# Altium schematic sheet Psoc4.SchDoc
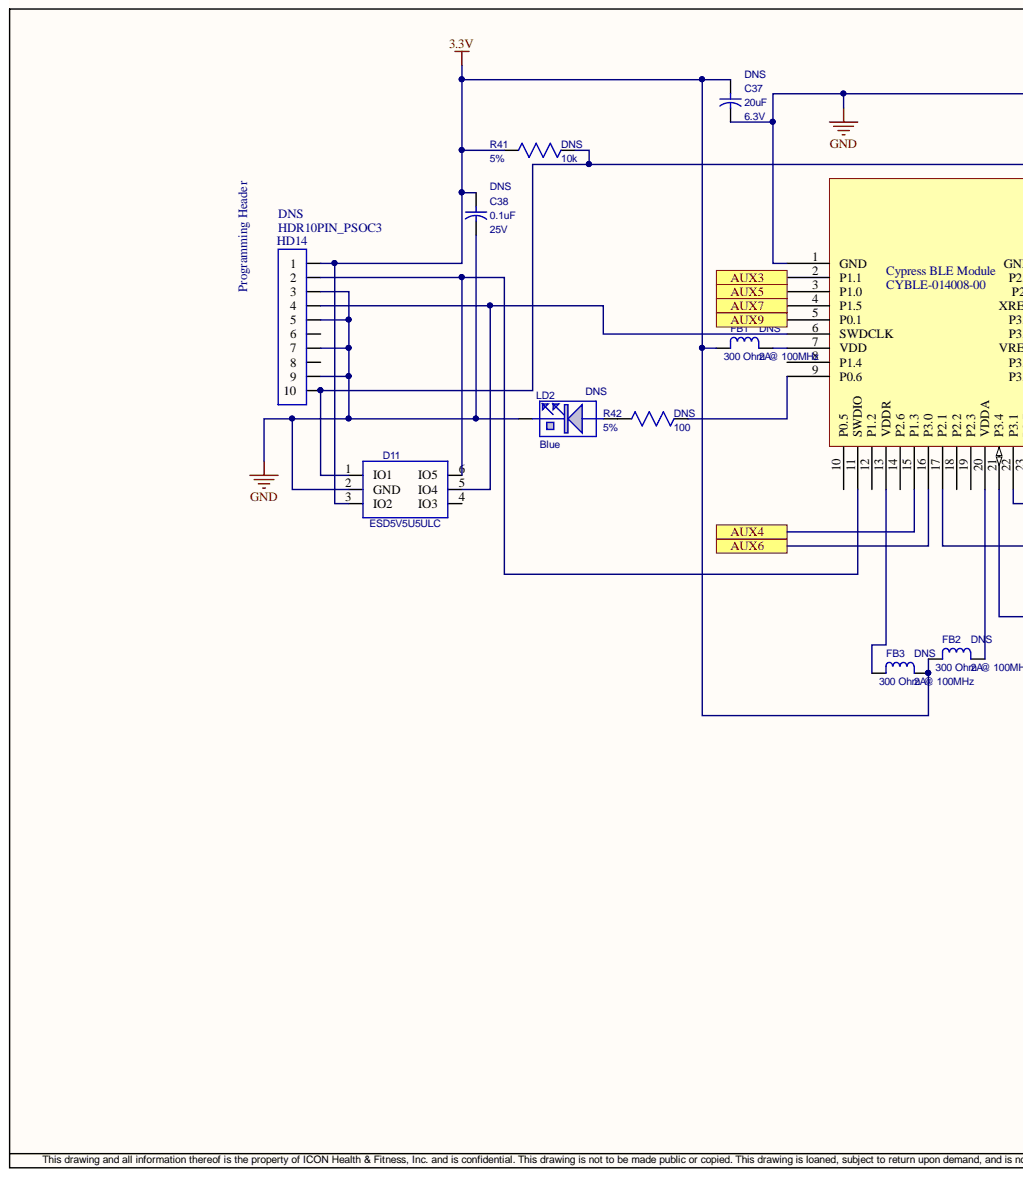
[diagram p0: Psoc4.SchDoc, left]
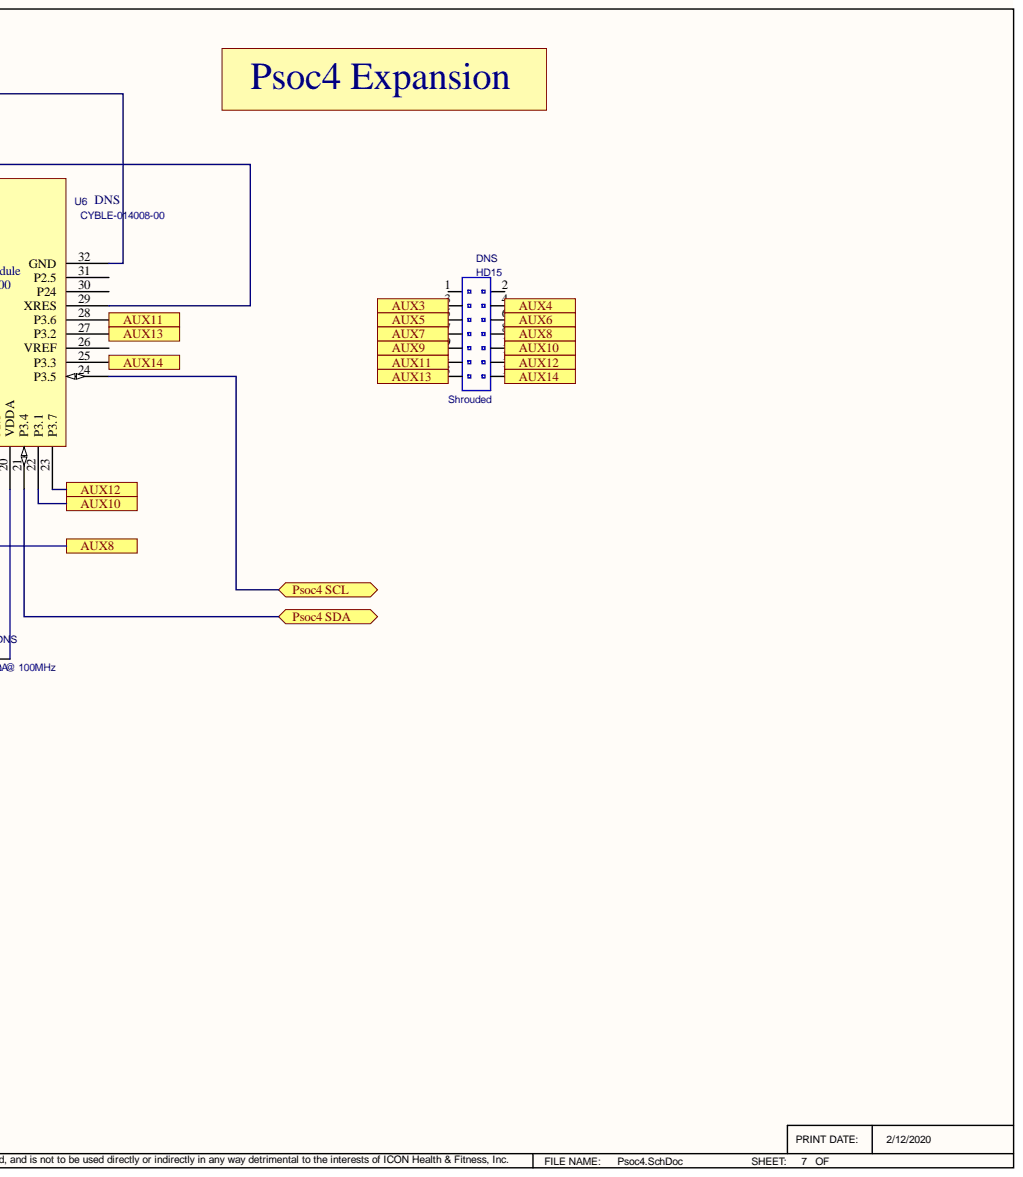
[diagram p1: Psoc4.SchDoc, right]

## Parts
C37  value 20uF  Voltage: 6.3V  [0402]  (hidden comment: 20uF_0402)
C38  value 0.1uF  Voltage: 25V  [C0201]  (hidden comment: CAP_NON_POLAR_SMT)
D11  ESD5V5U5ULC  [SOT457-6]
FB1  value 300 Ohm @ 100MHz  Amperage: 2A  [0603]  (hidden comment: FERRITE_BEAD)
FB2  value 300 Ohm @ 100MHz  Amperage: 2A  [0603]  (hidden comment: FERRITE_BEAD)
FB3  value 300 Ohm @ 100MHz  Amperage: 2A  [0603]  (hidden comment: FERRITE_BEAD)
HD14  HDR10PIN_PSOC3  ComponentType: Header  Notes: Programming Header  [HDR10PIN_PSOC3]
HD15  HeaderType: Shrouded  [HDR_2.5MM_14PIN_JST_XAD]  (hidden comment: HDR_14PIN_DUALROW_JST)
LD2  Color: Blue  [LED0603REFLOW-IPC]  (hidden comment: 0603_BLUE)
R41  value 10k  Tolerance: 5%  [0402]  (hidden comment: RESISTOR_SMT_5%)
R42  value 100  Tolerance: 5%  [0402]  (hidden comment: RESISTOR_SMT_5%)
U6  CYBLE-014008-00  ChipType: BLE Module  Package: Module  Vendor: Cypress CYBLE-014008-00  VendorName: Cypress  [CYBLE-014008-00]

## Ports
AUX3, AUX4, AUX5, AUX6, AUX7, AUX8, AUX9, AUX10, AUX11, AUX12, AUX13, AUX14, Psoc4 SCL, Psoc4 SDA

## Nets
3.3V: C37.1 C38.1 D11.3(IO2) FB1.1 FB2.1 FB3.2 HD14.1 R41.2
GND: C37.2 C38.2 D11.2(GND) HD14.3 HD14.5 HD14.7 HD14.9 LD2.1 U6.1(GND) U6.32(GND)
AUX3: HD15.3 U6.2(P1.1) ; port AUX3
AUX4: HD15.4 U6.15(P1.3) ; port AUX4
AUX5: HD15.5 U6.3(P1.0) ; port AUX5
AUX6: HD15.6 U6.16(P3.0) ; port AUX6
AUX7: HD15.7 U6.4(P1.5) ; port AUX7
AUX8: HD15.8 U6.17(P2.1) ; port AUX8
AUX9: HD15.9 U6.5(P0.1) ; port AUX9
AUX10: HD15.10 U6.22(P3.1) ; port AUX10
AUX11: HD15.11 U6.28(P3.6) ; port AUX11
AUX12: HD15.12 U6.23(P3.7) ; port AUX12
AUX13: HD15.13 U6.27(P3.2) ; port AUX13
AUX14: HD15.14 U6.25(P3.3) ; port AUX14
Psoc4 SCL: U6.24(P3.5) ; port Psoc4 SCL
Psoc4 SDA: U6.21(P3.4) ; port Psoc4 SDA
NetD11_1: D11.1(IO1) HD14.10 R41.1 U6.29(XRES)
NetD11_5: D11.5(IO4) HD14.4 U6.6(SWDCLK)
NetD11_6: D11.6(IO5) HD14.2 U6.11(SWDIO)
NetFB1_2: FB1.2 U6.7(VDD)
NetFB2_2: FB2.2 U6.20(VDDA)
NetFB3_1: FB3.1 U6.13(VDDR)
NetLD2_2: LD2.2 R42.2
NetR42_1: R42.1 U6.9(P0.6)

Not connected: D11 4(IO3); HD14 6 8; HD15 1 2; U6 8(P1.4) 10(P0.5) 12(P1.2) 14(P2.6) 18(P2.2) 19(P2.3) 26(VREF) 30(P24) 31(P2.5)

## Library data of the parts
C37 = 295734: Capacitor, 20uF, 6.3V, Monolithic, +/- 5%, Non-Polar, 0402, 2; Database Table Name: AltiumComponents; Tolerance: +/- 5%; CapType: Monolithic; Component Kind: Standard; ComponentLink1Description: Datasheet; ComponentLink4Description: PDM Parts Maintenance; ComponentLink4URL: https://pdm.iconfitness.com/PDM/EBOM/PartsMaintenance/PartsMaintenance.aspx?Editplidx=295734; ComponentType: Capacitor; Cost: 0.001; DNS: DNS; ModifyDate: 4/13/10; Package: 0402; Pins: 2; plIDX: 295734; Polarity: Non-Polar; Supplier 1: Digi-Key; CheckedBy: gdeguzman; CreatedBy: Brian Beardall; LastUpdatedBy: Brian Beardall; Library Name: bome.DbLib
C38 = 304055: Capacitor, 0.1uF, 25V, X5R, Ceramic, -20/+20%, Non-Polar, 0201, Fenghua: 0201X104M250NT or equivalent; Database Table Name: AltiumComponents; CapType: X5R; Component Kind: Standard; ComponentLink4Description: PDM Parts Maintenance; ComponentLink4URL: https://pdm.iconfitness.com/PDM/EBOM/PartsMaintenance/PartsMaintenance.aspx?Editplidx=304055; ComponentType: Capacitor; Composition: Ceramic; Cost: 0; DNS: DNS; Package: 0201; plIDX: 304055; Polarity: Non-Polar; Tolerance: -20/+20%; Vendor: Fenghua: 0201X104M250NT or equivalent; CheckedBy: Sam Rhoufiry; CreatedBy: Sara Lin; LastUpdatedBy: Steven Zhou; Library Name: bome.DbLib
D11 = 325030: Diode, ESD5V5U5ULC, TVS, 5V, TSOP6, Infineon: ESD5V5U5ULC; Database Table Name: AltiumComponents; IDFCOMP: 0; Component Kind: Standard; ComponentLink1Description: Datasheet; ComponentLink1URL: \\iconfitness.com\loganfiles\RND Departments\electronics\accel_lib\datasheets\Infineon-ESD5V5U5ULC-DS-v01_04-EN.pdf; ComponentLink4Description: PDM Parts Maintenance; ComponentLink4URL: https://pdm.iconfitness.com/PDM/EBOM/PartsMaintenance/PartsMaintenance.aspx?Editplidx=325030; ComponentType: Diode; Cost: 0.08; Datasheet: \\langley\electronics\accel_lib\datasheets\D\PESDXS4UD-NXP.pdf; DiodeType: TVS; ModifyDate: 07/31/2009 12:30:23; Package: TSOP6; plIDX: 325030; Value: ESD5V5U5ULC; Vendor: Infineon: ESD5V5U5ULC; Voltage: 5V; CheckedBy: T. Hayes; CreatedBy: Rui Zhang; LastUpdatedBy: Rui Zhang; Library Name: bome.DbLib
FB1-FB3 = 295882: Inductor, 300 Ohm @ 100MHz, Ferrite Bead, SMT, 0603 (1608 Metric), 2A, 2 Pins, Wurth Electronics: 742792641 or equivalent; Database Table Name: AltiumComponents; Component Kind: Standard; ComponentLink4Description: PDM Parts Maintenance; ComponentLink4URL: https://pdm.iconfitness.com/PDM/EBOM/PartsMaintenance/PartsMaintenance.aspx?Editplidx=295882; ComponentType: Inductor; Cost: 0; DNS: DNS; InductorType: Ferrite Bead; Leads: SMT; Package: 0603 (1608 Metric); Pins: 2; plIDX: 295882; Vendor: Wurth Electronics: 742792641 or equivalent; CreatedBy: Mike Tyger; LastUpdatedBy: Mike Tyger; Library Name: bome.DbLib
  FB1: Value: 300 Ohm @ 100MHz
HD14 = 18843: Header, 10 Pins, Samtec: FTSH-105-01-L-DV-K; Database Table Name: AltiumComponents; Component Kind: Standard; ComponentLink4Description: PDM Parts Maintenance; ComponentLink4URL: https://pdm.iconfitness.com/PDM/EBOM/PartsMaintenance/PartsMaintenance.aspx?Editplidx=18843; Cost: 0.15; DNS: DNS; Pin: 10-pin; Pins: 10; plIDX: 18843; Value: FTSH-105-01-L-DV-K; Vendor: Samtec: FTSH-105-01-L-DV-K; Library Name: bome.DbLib
HD15 = 365385: Header, 14 Pins, 2.50mm, Vertical, Through hole, double row, Shrouded, JST: B14B-XADSS-N-A; Database Table Name: AltiumComponents; Component Kind: Standard; ComponentLink1Description: Datasheet; ComponentLink1URL: \\iconfitness.com\loganfiles\RND Departments\electronics\accel_lib\Datasheets\eXA1.pdf; ComponentLink4Description: PDM Parts Maintenance; ComponentLink4URL: https://pdm.iconfitness.com/PDM/EBOM/PartsMaintenance/PartsMaintenance.aspx?Editplidx=365385; ComponentType: Header; Cost: 0; DNS: DNS; LeadSpacing: 2.50mm; Package: Vertical, Through hole, double row; Pins: 14; plIDX: 365385; Value: B14B-XADSS-N-A; Vendor: JST: B14B-XADSS-N-A; CreatedBy: Ross Bunnell; LastUpdatedBy: Ross Bunnell; Library Name: bome.DbLib
LD2 = 16659: LED, Blue, Ultrabright SMD, 0603, Vendor: Lite-on, LTST-C190UBKT; Database Table Name: AltiumComponents; IDF_COMP: 1; PackageOutlineLayer: PackageOutline; ComponentHeight: 32mil; Component Kind: Standard; ComponentLink4Description: PDM Parts Maintenance; ComponentLink4URL: https://pdm.iconfitness.com/PDM/EBOM/PartsMaintenance/PartsMaintenance.aspx?Editplidx=16659; ComponentType: LED; Cost: 0.08; DNS: DNS; HelpURL: http://www.laureanno.com/RC/LED-calc.htm; LEDType: Ultrabright SMD; ModifyDate: 02/08/2007 16:01:09; Package: 0603; Pins: 2; plIDX: 16659; Supplier 1: Digi-Key; Supplier Part Number 1: LTST-C190UBKT-ND; Vendor: Lite-on; VendorNumber: LTST-C190UBKT; CheckedBy: Scott Travis; Datasheet: G:\accel_lib\datasheets\LTST-C190UBKT.pdf; LastUpdatedBy: Kimberly Barker; Library Name: bome.DbLib
R41 = 19590: Resistor, 10k, ThickFilm, 5%, 0402, 1/10W, Any; Database Table Name: AltiumComponents; Component Kind: Standard; ComponentLink4Description: PDM Parts Maintenance; ComponentLink4URL: https://pdm.iconfitness.com/PDM/EBOM/PartsMaintenance/PartsMaintenance.aspx?Editplidx=19590; ComponentType: Resistor; Composition: ThickFilm; Cost: 0.0004; DNS: DNS; Package: 0402; plIDX: 19590; Vendor: Any; Wattage: 1/10W; CheckedBy: gdeguzman; LastUpdatedBy: Mike Tyger; Library Name: bome.DbLib
R42 = 20445: Resistor, 100, ThickFilm, 5%, 0402, 1/10W, Any; Database Table Name: AltiumComponents; Component Kind: Standard; ComponentLink4Description: PDM Parts Maintenance; ComponentLink4URL: https://pdm.iconfitness.com/PDM/EBOM/PartsMaintenance/PartsMaintenance.aspx?Editplidx=20445; ComponentType: Resistor; Composition: ThickFilm; Cost: 0.001; DNS: DNS; Package: 0402; plIDX: 20445; Vendor: Any; Wattage: 1/10W; CheckedBy: gdeguzman; LastUpdatedBy: Mike Tyger; Library Name: bome.DbLib
U6 = 309168: IC, BLE Module, Module, 31 Pins, Cypress CYBLE-014008-00; Database Table Name: AltiumComponents; Component Kind: Standard; ComponentLink1Description: Datasheet; ComponentLink1URL: http://www.cypress.com/products/ez-ble-psoc-module-bluetooth-smart; ComponentLink4Description: PDM Parts Maintenance; ComponentLink4URL: https://pdm.iconfitness.com/PDM/EBOM/PartsMaintenance/PartsMaintenance.aspx?Editplidx=309168; ComponentType: IC; Cost: 0; Datasheet: http://www.cypress.com/products/ez-ble-psoc-module-bluetooth-smart; DNS: DNS; HelpURL: http://www.cypress.com/products/ez-ble-psoc-module-bluetooth-smartf; ModifyDate: 11/24/2008 09:24:33; Pins: 31; plIDX: 309168; CheckedBy: Rich; CreatedBy: Rich Johns; LastUpdatedBy: Rich Johns; Library Name: bome.DbLib
